# Altium schematic sheet EXP1-CASSA.SchDoc
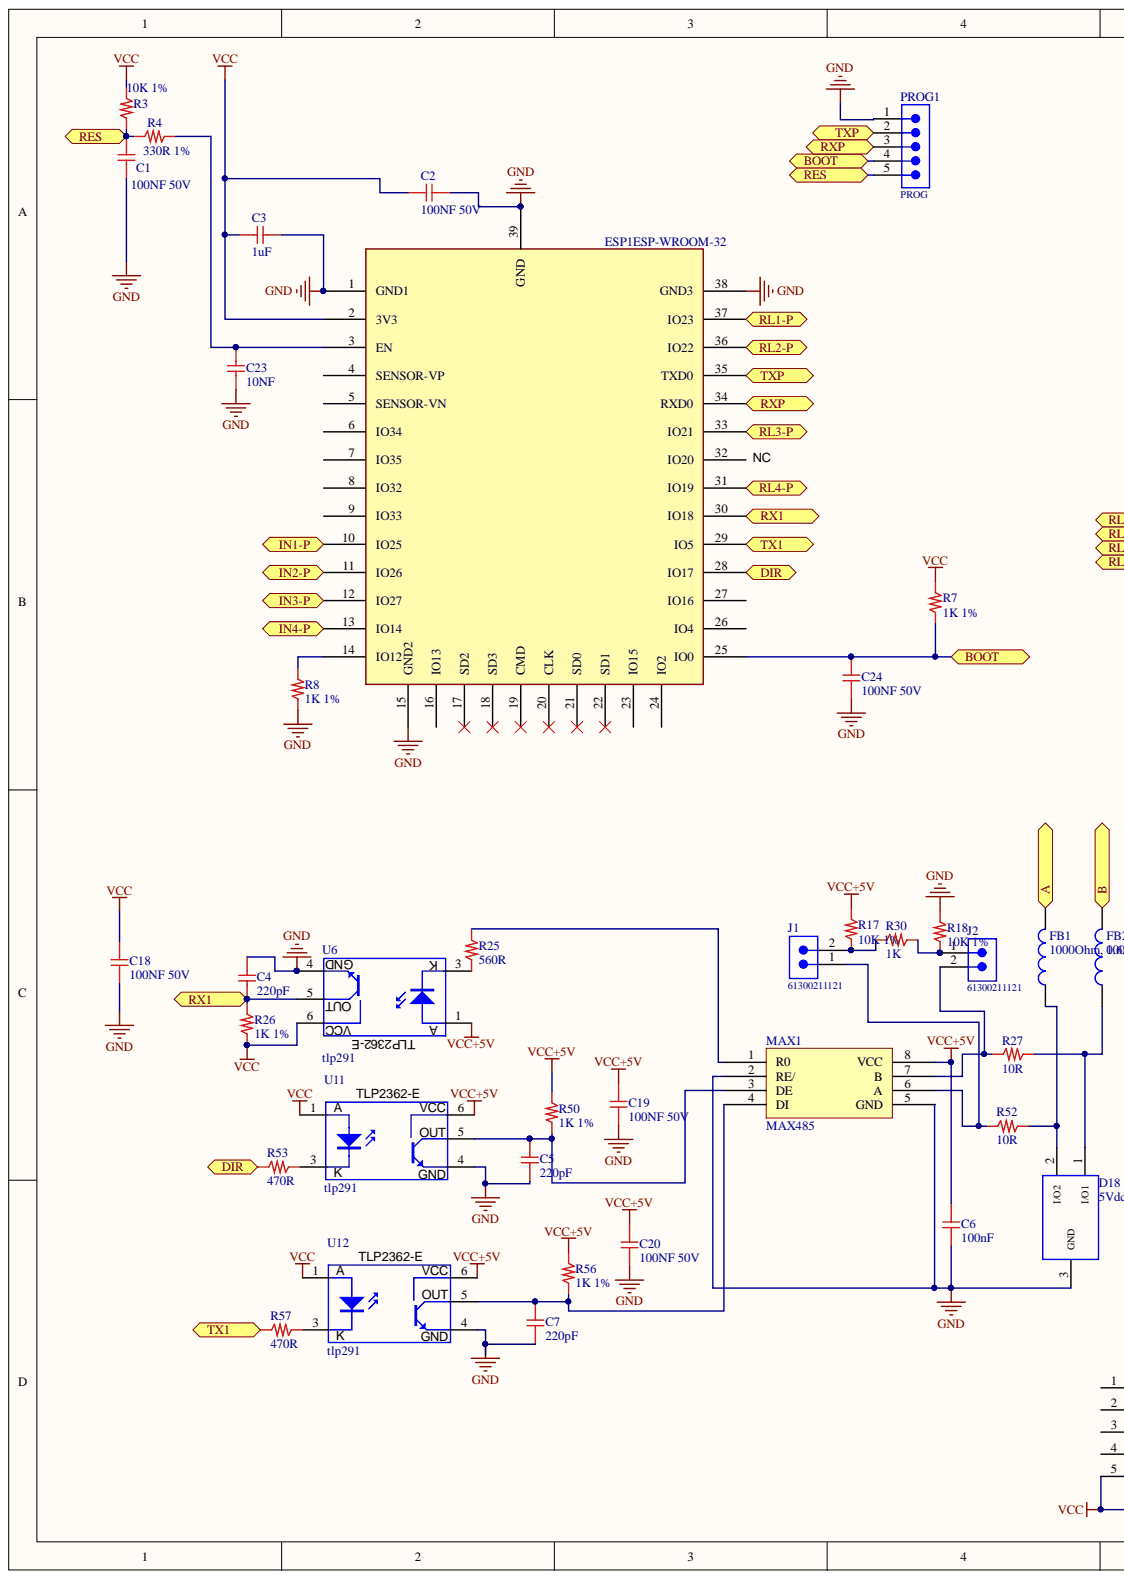
[diagram p0: EXP1-CASSA.SchDoc, left]
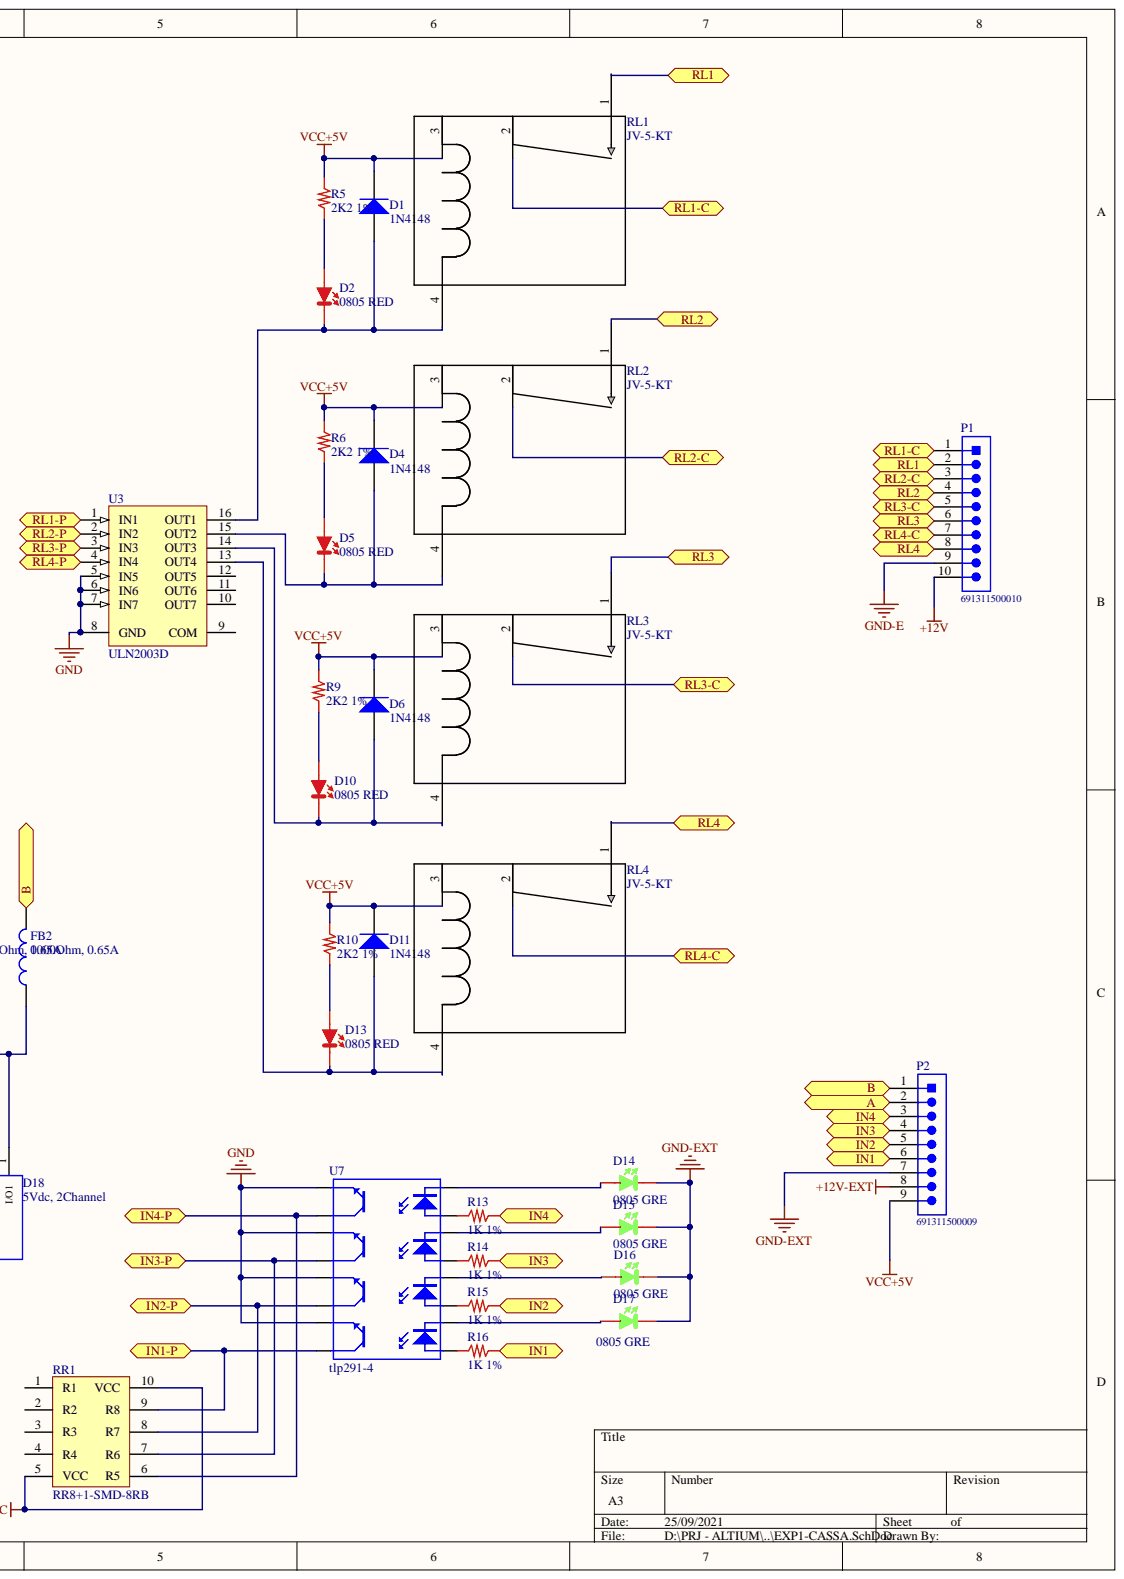
[diagram p1: EXP1-CASSA.SchDoc, right]

## Parts
C1  100NF 50V  [0603_c]
C2  100NF 50V  [0603_c]
C3  1uF  [0603_c]
C4  220pF  [0402_C]
C5  220pF  [0402_C]
C6  100nF  [0805_C]
C7  220pF  [0402_C]
C18  100NF 50V  [0603_c]
C19  100NF 50V  [0603_c]
C20  100NF 50V  [0603_c]
C23  10NF  [0603_c]
C24  100NF 50V  [0603_c]
D1  1N4148  [1N4148]
D2  0805 RED  [0805_Red]
D4  1N4148  [1N4148]
D5  0805 RED  [0805_Red]
D6  1N4148  [1N4148]
D10  0805 RED  [0805_Red]
D11  1N4148  [1N4148]
D13  0805 RED  [0805_Red]
D14  0805 GRE  [0805_Green]
D15  0805 GRE  [0805_Green]
D16  0805 GRE  [0805_Green]
D17  0805 GRE  [0805_Green]
D18  5Vdc, 2Channel  [WE-TVS_Standard_SOT23-3L]
ESP1  ESP-WROOM-32  [ESP32]
FB1  1000Ohm, 0.65A  [WE-CBF_0603_Wide Band]
FB2  1000Ohm, 0.65A  Rated Current: 0.65A  [WE-CBF_0603_Wide Band]
J1  61300211121  [61300211121]
J2  61300211121  [61300211121]
MAX1  MAX485  [SO-8]
P1  691311500010  [691311500010]
P2  691311500009  [691311500009]
PROG1  PROG  [PROG1 - TR]
R3  10K 1%  [0603_R]
R4  330R 1%  [0603_R]
R5  2K2 1%  [0805_R]
R6  2K2 1%  [0805_R]
R7  1K 1%  [0603_R]
R8  1K 1%  [0603_R]
R9  2K2 1%  [0805_R]
R10  2K2 1%  [0805_R]
R13  1K 1%  [0805_R]
R14  1K 1%  [0805_R]
R15  1K 1%  [0805_R]
R16  1K 1%  [0805_R]
R17  10K 1%  [0603_R]
R18  10K 1%  [0603_R]
R25  560R  [0603_R]
R26  1K 1%  [0603_R]
R27  10R  [0805_R]
R30  1K  [0805_R]
R50  1K 1%  [0603_R]
R52  10R  [0805_R]
R53  470R  [0603_R]
R56  1K 1%  [0603_R]
R57  470R  [0603_R]
RL1  JV-5-KT  [JV-5-KT]
RL2  JV-5-KT  [JV-5-KT]
RL3  JV-5-KT  [JV-5-KT]
RL4  JV-5-KT  [JV-5-KT]
RR1  RR8+1-SMD-8RB  [RR8+1-SMD]
U3  ULN2003D  [SO16_N]
U6  tlp291  [TLP2362-E]
U7  tlp291-4  [SO16_NO]
U11  tlp291  [TLP2362-E]
U12  tlp291  [TLP2362-E]

## Ports
A, B, BOOT, DIR, IN1, IN1-P, IN2, IN2-P, IN3, IN3-P, IN4, IN4-P, RES, RL1, RL1-C, RL1-P, RL2, RL2-C, RL2-P, RL3, RL3-C, RL3-P, RL4, RL4-C, RL4-P, RX1, RXP, TX1, TXP

## Nets
+12V: P1.10
+12V-EXT: P2.8
GND: C1.1 C2.2 C3.2 C4.1 C5.2 C6.2 C7.2 C18.1 C19.1 C20.1 C23.2 C24.2 D18.3 ESP1.1(GND1) ESP1.15(GND2) ESP1.38(GND3) ESP1.39(GND) MAX1.2(RE/) MAX1.5(GND) PROG1.1 R8.2 R18.1 U3.5(IN5) U3.6(IN6) U3.7(IN7) U3.8(GND) U6.4 U7.9 U7.11 U7.13 U7.15 U11.4 U12.4
GND-E: P1.9
GND-EXT: D14.K D15.K D16.K D17.K P2.7
VCC: C2.1 C3.1 C18.2 ESP1.2(3V3) R3.2 R7.1 R26.2 RR1.5(VCC) RR1.10(VCC) U6.6 U11.1 U12.1
VCC+5V: C6.1 C19.2 C20.2 D1.2 D4.2 D6.2 D11.2 MAX1.8(VCC) P2.9 R5.1 R6.1 R9.1 R10.1 R17.1 R50.1 R56.1 RL1.3 RL2.3 RL3.3 RL4.3 U6.1 U11.6 U12.6
A: FB1.2 P2.2 ; port A
B: FB2.2 P2.1 ; port B
BOOT: C24.1 ESP1.25(IO0) PROG1.4 R7.2 ; port BOOT
DIR: ESP1.28(IO17) R53.1 ; port DIR
IN1: P2.6 R16.2 ; port IN1
IN1-P: ESP1.10(IO25) RR1.9(R8) U7.16 ; port IN1-P
IN2: P2.5 R15.2 ; port IN2
IN2-P: ESP1.11(IO26) RR1.8(R7) U7.14 ; port IN2-P
IN3: P2.4 R14.2 ; port IN3
IN3-P: ESP1.12(IO27) RR1.7(R6) U7.12 ; port IN3-P
IN4: P2.3 R13.2 ; port IN4
IN4-P: ESP1.13(IO14) RR1.6(R5) U7.10 ; port IN4-P
RES: C1.2 PROG1.5 R3.1 R4.1 ; port RES
RL1: P1.2 RL1.1 ; port RL1
RL1-C: P1.1 RL1.2 ; port RL1-C
RL1-P: ESP1.37(IO23) U3.1(IN1) ; port RL1-P
RL2: P1.4 RL2.1 ; port RL2
RL2-C: P1.3 RL2.2 ; port RL2-C
RL2-P: ESP1.36(IO22) U3.2(IN2) ; port RL2-P
RL3: P1.6 RL3.1 ; port RL3
RL3-C: P1.5 RL3.2 ; port RL3-C
RL3-P: ESP1.33(IO21) U3.3(IN3) ; port RL3-P
RL4: P1.8 RL4.1 ; port RL4
RL4-C: P1.7 RL4.2 ; port RL4-C
RL4-P: ESP1.31(IO19) U3.4(IN4) ; port RL4-P
RX1: C4.2 ESP1.30(IO18) R26.1 U6.5 ; port RX1
RXP: ESP1.34(RXD0) PROG1.3 ; port RXP
TX1: ESP1.29(IO5) R57.1 ; port TX1
TXP: ESP1.35(TXD0) PROG1.2 ; port TXP
NetC5_1: C5.1 MAX1.3(DE) R50.2 U11.5
NetC7_1: C7.1 MAX1.4(DI) R56.2 U12.5
NetC23_1: C23.1 ESP1.3(EN) R4.2
NetD1_1: D1.1 D2.K RL1.4 U3.16(OUT1)
NetD2_A: D2.A R5.2
NetD4_1: D4.1 D5.K RL2.4 U3.15(OUT2)
NetD5_A: D5.A R6.2
NetD6_1: D6.1 D10.K RL3.4 U3.14(OUT3)
NetD10_A: D10.A R9.2
NetD11_1: D11.1 D13.K RL4.4 U3.13(OUT4)
NetD13_A: D13.A R10.2
NetD14_A: D14.A U7.8
NetD15_A: D15.A U7.6
NetD16_A: D16.A U7.4
NetD17_A: D17.A U7.2
NetD18_1: D18.1 FB2.1 R27.2
NetD18_2: D18.2 FB1.1 R52.2
NetESP1_14: ESP1.14(IO12) R8.1
NetJ1_1: J1.1 MAX1.6(A) R52.1
NetJ1_2: J1.2 R17.2 R30.2
NetJ2_1: J2.1 R18.2 R30.1
NetJ2_2: J2.2 MAX1.7(B) R27.1
NetMAX1_1: MAX1.1(R0) R25.2
NetR13_1: R13.1 U7.7
NetR14_1: R14.1 U7.5
NetR15_1: R15.1 U7.3
NetR16_1: R16.1 U7.1
NetR25_1: R25.1 U6.3
NetR53_2: R53.2 U11.3
NetR57_2: R57.2 U12.3(K)

Not connected: ESP1 4(SENSOR-VP) 5(SENSOR-VN) 6(IO34) 7(IO35) 8(IO32) 9(IO33) 16(IO13) 17(SD2) 18(SD3) 19(CMD) 20(CLK) 21(SD0) 22(SD1) 23(IO15) 24(IO2) 26(IO4) 27(IO16) 32(IO20); RR1 1(R1) 2(R2) 3(R3) 4(R4); U3 9(COM) 10(OUT7) 11(OUT6) 12(OUT5)

## Library data of the parts
C1 C2 C18-C20 C24 = 100NF 50V: Cap X7R 100nF 50V Flex Terminal; Cod. Interno: COCS50665050104; Cod. Produttore: CL10B104KB8SFNC; Produttore: Samsung; Cod. DigiKey: 1276-1936-2-ND; Component Kind: Standard; Library Name: CapSMD0603.SchLib
C3 = 1uF 10V: 2.2 µF, 10 V, ± 10%, X5R 0603; Cod. Interno: COCS??; Cod. Produttore: 0603X225K100CT; Costo 100: 0,0436; Costo 1000: 0,0183; Produttore: WALSIN; Component Kind: Standard; ComponentLink1Description: FARNELL; ComponentLink1URL: http://it.farnell.com/walsin/0603x225k100ct/cond-mlcc-x5r-2-2uf-10v-0603-bob/dp/2495422?st=2.2uf; Library Name: CapSMD0603.SchLib
C4 C5 C7 = 220pF 50V: Cap COG 220pF 50V; Cod. Interno: COCS506565000221; Cod. Produttore: ??; Component Kind: Standard; Library Name: CapSMD0402.SchLib; Produttore: ??
C6 = 100nF 100V: Cap X7R 100nF 100V; Cod. Interno: COCS 557051010104; Cod. Produttore: ??; Component Kind: Standard; Library Name: CapSMD0805.SchLib; Produttore: ??
C23 = 10NF 50V: Cap X7R 10nF 50V; Cod. Interno: COCS 556655000103; Cod. Produttore: CL05B103KB5NNNC; Produttore: Samsung; Cod. DigiKey: 1276-1028-2-ND; Component Kind: Standard; Library Name: CapSMD0603.SchLib
D1 D4 D6 D11 = 1N4148: High Conductance Fast Diode; Code_JEDEC: DO-204-AH; Component Kind: Standard; ComponentLink1Description: Manufacturer Link; ComponentLink1URL: http://www.fairchildsemi.com/; ComponentLink2Description: Datasheet; ComponentLink2URL: http://www.fairchildsemi.com/ds/1N%2F1N4148.pdf; DatasheetVersion: 1997; LatestRevisionDate: 17-Jul-2002; LatestRevisionNote: Re-released for DXP Platform.; PackageDescription: Diode, Axial; Body 3.8 x 1.9 mm (LxDia. max), Lead Dia. 0.53 mm (max); PackageReference: DO-35; Published: 8-Jun-2000; Library Name: HSW.SchLib; Publisher: Altium Limited
D2 D5 D10 D13 = LED_ROSSO_0805: LED Rosso 0805; Cod. Interno: LEDS 2AC080RS7500; Cod. Produttore: 150080RS75000; Luminosità: 150mcd; Produttore: WE; V: 2.0V; Component Kind: Standard; Library Name: DiodiLED.SchLib
D14-D17 = LED_Verde_0805: LED Verde 0805; Cod. Interno: LEDS 9AC080GS7500; Cod. Produttore: 150080GS75000; Luminosità: 450mcd; Produttore: WE; V: 3.1V; Component Kind: Standard; Library Name: DiodiLED.SchLib
D18 = 824022: WE-TVS TVS Diode - Standard Series, SOT23-3L, 5Vdc, 2 Channel; Channel: 2; Channel Input Capacitance: 12pF; DC Operating Voltage: 5V; Reverse Breakdown Voltage min.: 6.1V; Reverse Channel Leakage Current: 2.5uA; Reverse Peak Pulse Current: 8A; Component Kind: Standard; ComponentLink1Description: Manufacturer; ComponentLink1URL: http://www.we-online.de; ComponentLink2Description: Datasheet; ComponentLink2URL: https://katalog.we-online.com/pbs/datasheet/824022.pdf; Library Name: WE-TVS.SchLib; Mounting Technology: SMT; Operating Temperature: -55 to +125degC; Packaging: Tape and Reel; Part Number: 824022; Published Date: June 2019; Size: SOT23-3L; Publisher: Wurth Elektronik
ESP1 = ESP32: Component Kind: Standard; Library Name: HSW.SchLib
FB1 FB2 = 74279266: WE-CBF SMT EMI Suppression Ferrite Bead, size 0603, 1000Ohm, 0.65A; DC Resistance max.: 0.6Ohm; Impedance max.: 1350Ohm; Type: Wide Band; Component Kind: Standard; ComponentLink1Description: Manufacturer; ComponentLink1URL: http://www.we-online.de; ComponentLink2Description: Datasheet; ComponentLink2URL: https://katalog.we-online.com/pbs/datasheet/74279266.pdf; Impedance@100 MHz: 1000Ohm; Library Name: WE-CBF_0603.SchLib; Mounting Technology: SMT; Operating Temperature: -55 to +125degC; Packaging: Tape and Reel; Part Number: 74279266; Published Date: March 2019; Size: 0603; Publisher: Wurth Elektronik
  FB1: Rated Current: 0.65A
J1 J2 = 61300211121: THT Vertical Pin Header WR-PHD, Pitch 2.54 mm, Single Row, 2 pins; Contact Resistance: 20 mOhm; Gender: Male; Pin Number: 2; Pitch: 2.54 mm; Rated Current: 3 A; Withstanding Voltage: 500 VAC; Working Voltage: 250 VAC; Component Kind: Standard; ComponentLink1Description: Manufacturer; ComponentLink1URL: http://www.we-online.de; ComponentLink2Description: Datasheet; ComponentLink2URL: https://katalog.we-online.de/em/datasheet/6130xx11121.pdf; Library Name: Pin Header WR-PHD_2.54 mm.SchLib; Mounting Technology: THT; Operating Temperature: -40 to 105 degC; Orientation: Vertical; Packaging: Bag; Part Number: 61300211121; Published Date: August 2018; Row Number: Single; Publisher: Wurth Elektronik
P1 = 691311500010: Serie 311 - 5.08mm Open Vertical PCB Header WR-TBL, 10 pin; Gender: Male; Pin Number: 10; Component Kind: Standard; ComponentLink1Description: Manufacturer; ComponentLink1URL: http://www.we-online.de; ComponentLink2Description: Datasheet; ComponentLink2URL: http://katalog.we-online.de/em/datasheet/6913115000xx.pdf; Library Name: Pluggable PCB System WR-TBL_5.08 mm.SchLib; Mounting Technology: THT; Operating Temperature: -40 to 105 degC; Packaging: Box; Part Number: 691311500010; Pitch: 5.08 mm; Published Date: July 2018; Publisher: Wurth Elektronik
P2 = 691311500009: Serie 311 - 5.08mm Open Vertical PCB Header WR-TBL, 9 pin; Gender: Male; Pin Number: 9; Component Kind: Standard; ComponentLink1Description: Manufacturer; ComponentLink1URL: http://www.we-online.de; ComponentLink2Description: Datasheet; ComponentLink2URL: http://katalog.we-online.de/em/datasheet/6913115000xx.pdf; Library Name: Pluggable PCB System WR-TBL_5.08 mm.SchLib; Mounting Technology: THT; Operating Temperature: -40 to 105 degC; Packaging: Box; Part Number: 691311500009; Pitch: 5.08 mm; Published Date: July 2018; Publisher: Wurth Elektronik
PROG1 = PROG1-TR: SMT Vertical Pin Header WR-PHD, Pitch 2.54 mm, Single Row, 6 pins; Part Number: 61000618321; Contact Resistance: 20 mOhm; Gender: Male; Pin Number: 6; Pitch: 2.54 mm; Rated Current: 3 A; Withstanding Voltage: 500 VAC; Working Voltage: 250 VAC; Component Kind: Standard; ComponentLink1Description: Manufacturer; ComponentLink1URL: http://www.we-online.de; ComponentLink2Description: Datasheet; ComponentLink2URL: https://katalog.we-online.de/em/datasheet/6100xx18321.pdf; Mounting Technology: SMT; Operating Temperature: -40 to 125 degC; Orientation: Vertical; Packaging: Tube; Published Date: August 2018; Row Number: Single; Library Name: HSW.SchLib; Publisher: Wurth Elektronik
R3 R4 R7 R8 R26 R50 R56 = 1K 1%: Res Film Spesso 1/16W 1K 1%; Cod. Interno: RESS4166356B0102; Cod. Produttore: ??; Component Kind: Standard; Library Name: ResSMD0603.SchLib; Produttore: ??
R5 R6 R9 R10 = 2K2 1%: Res Film Spesso 0805 1/2W 2K2 1%; Cod. Interno: ??; Component Kind: Standard; Library Name: ResSMD0805.SchLib
R13-R16 = 1K 1%: Res Film Spesso 1/2W 1K 1%; Cod. Interno: RESS4170555B0122??; Potenza: 1/2W 5%; Component Kind: Standard; Library Name: ResSMD0805.SchLib
R17 R18 = 10K 1%: Res Film Spesso 1/16W 10K 1%; Cod. Interno: RESS4166356B0103; Cod. Produttore: ERJ-3EKF1002V; Produttore: Panasonic; Component Kind: Standard; Library Name: ResSMD0603.SchLib
R25 = 560R: Res Film Spesso 1/16W 560R 5%; Cod. Interno: RESS 4166556B0561; Component Kind: Standard; Library Name: ResSMD0603.SchLib
R27 R52 = 10R: Res Film Spesso 1/8W 10R 5%; Cod. Interno: RESS4170555B0100; Potenza: 1/8W 5%; Component Kind: Standard; Library Name: ResSMD0805.SchLib
R30 = 1K: Res Film Spesso 1/2W 1K 5%; Cod. Interno: RESS4170555B0122??; Potenza: 1/2W 5%; Component Kind: Standard; Library Name: ResSMD0805.SchLib
R53 R57 = 470R: Res Film Spesso 1/16W 470R 5%; Cod. Interno: RESS4166556B0471??; Cod. Produttore: ??; Component Kind: Standard; Library Name: ResSMD0603.SchLib; Produttore: ??
RL1-RL4 = JV-5S-KT: RELE JV-5-KT RELE 10A; Manufacturer_Part_Number: ALDP106W; Built_By: EMA_UL_Team; Vendor: Panasonic; Component Kind: Standard; RefDes: RefDes; Type: DEV; Value: Value; Copyright: Copyright (C) 2018 Accelerated Designs. All rights reserved; Library Name: HSW.SchLib
U3 = ULN2003D: Seven Darlington Array; Code_IPC: SOIC127P600-16; Component Kind: Standard; ComponentLink1Description: Manufacturer Link; ComponentLink1URL: http://www.st.com/; ComponentLink2Description: Datasheet; ComponentLink2URL: http://www.st.com/stonline/products/literature/ds/5279/uln2001.pdf; DatasheetVersion: Sep-1998; LatestRevisionDate: 13-Apr-2006; LatestRevisionNote: IPC-7351 Footprint Added.; PackageDescription: 16-Pin Small Outline Integrated Circuit 1.27 mm Pitch; PackageReference: SO16; PackageVersion: May-1998; Published: 8-Jun-2000; Library Name: HSW.SchLib; Publisher: Altium Limited
U6 U11 U12 = TLP2362-E: 4-Pin Phototransistor Optocoupler; Component Kind: Standard; DatasheetVersion: Nov-2003; LatestRevisionDate: 04-Mar-2005; LatestRevisionNote: Sch pins 3 & 4 Swapped.; PackageReference: DIP-4; Published: 24-Mar-1999; Library Name: HSW.SchLib; Publisher: Altium Limited
U7 = tlp291_4: 4-Pin Phototransistor Optocoupler; Component Kind: Standard; DatasheetVersion: Nov-2003; LatestRevisionDate: 04-Mar-2005; LatestRevisionNote: Sch pins 3 & 4 Swapped.; PackageReference: DIP-4; Published: 24-Mar-1999; Library Name: HSW.SchLib; Publisher: Altium Limited
MAX1 = MAX485: Component Kind: Standard; Library Name: HSW.SchLib
RR1 = RR8+1-SMD-8RB: Component Kind: Standard; Library Name: HSW.SchLib
